# Altium schematic sheet OXCO_DaughterCard_Main.schdoc(OXCO_DaughterCard_Main)
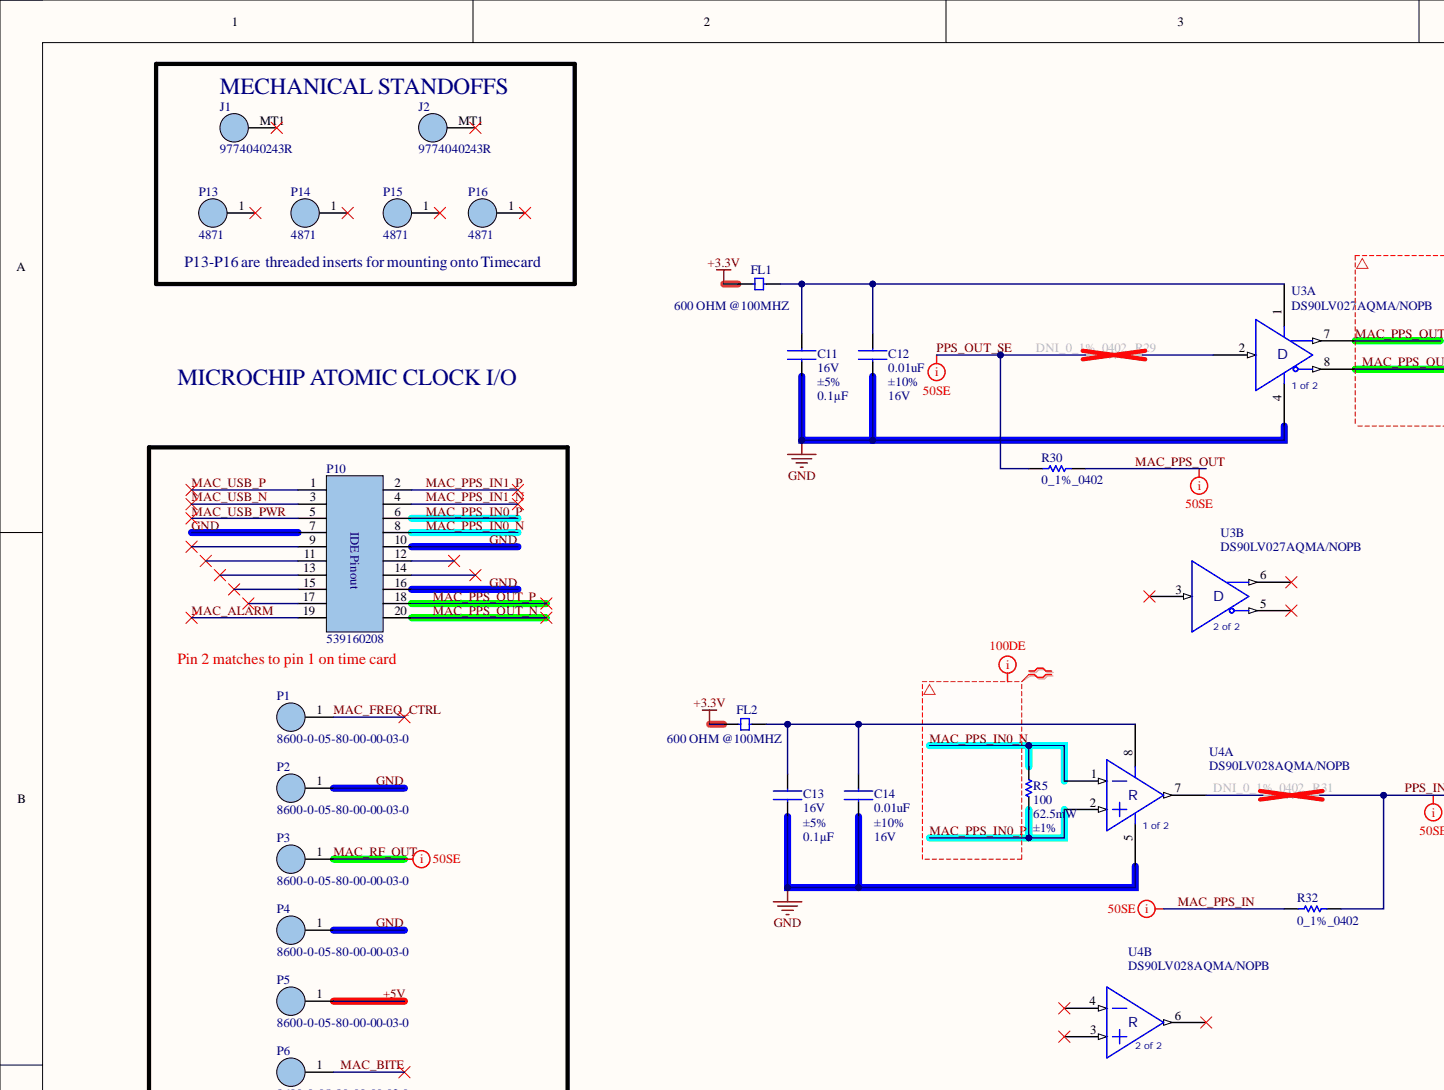
[diagram p0: OXCO_DaughterCard_Main.schdoc, top left]
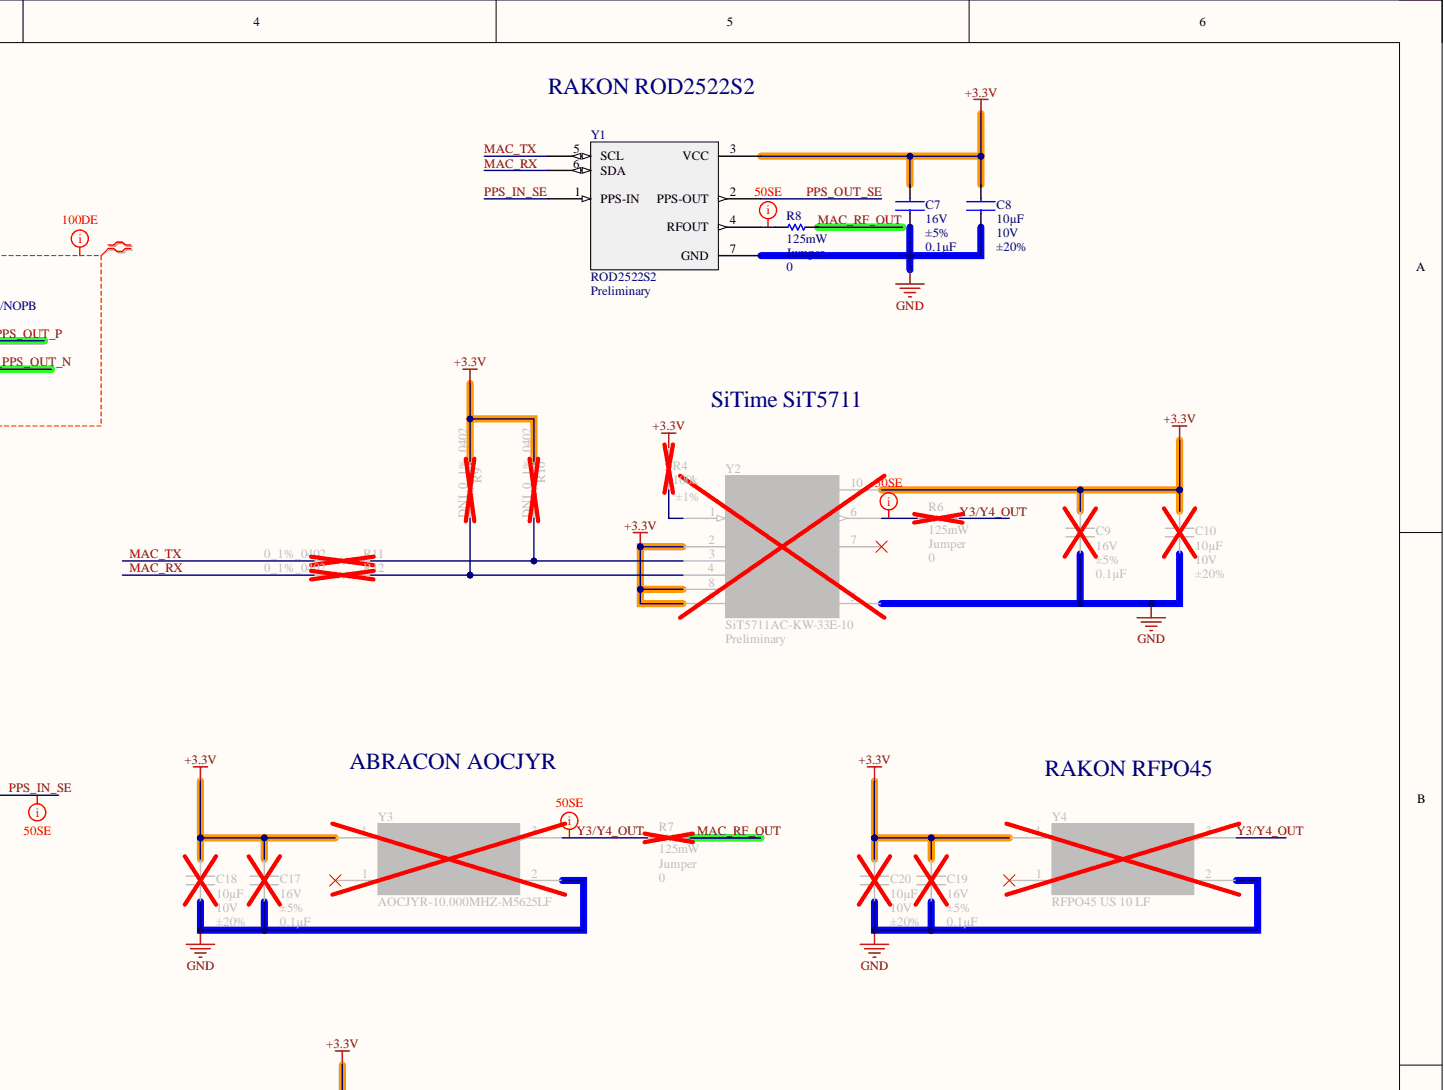
[diagram p1: OXCO_DaughterCard_Main.schdoc, top right]
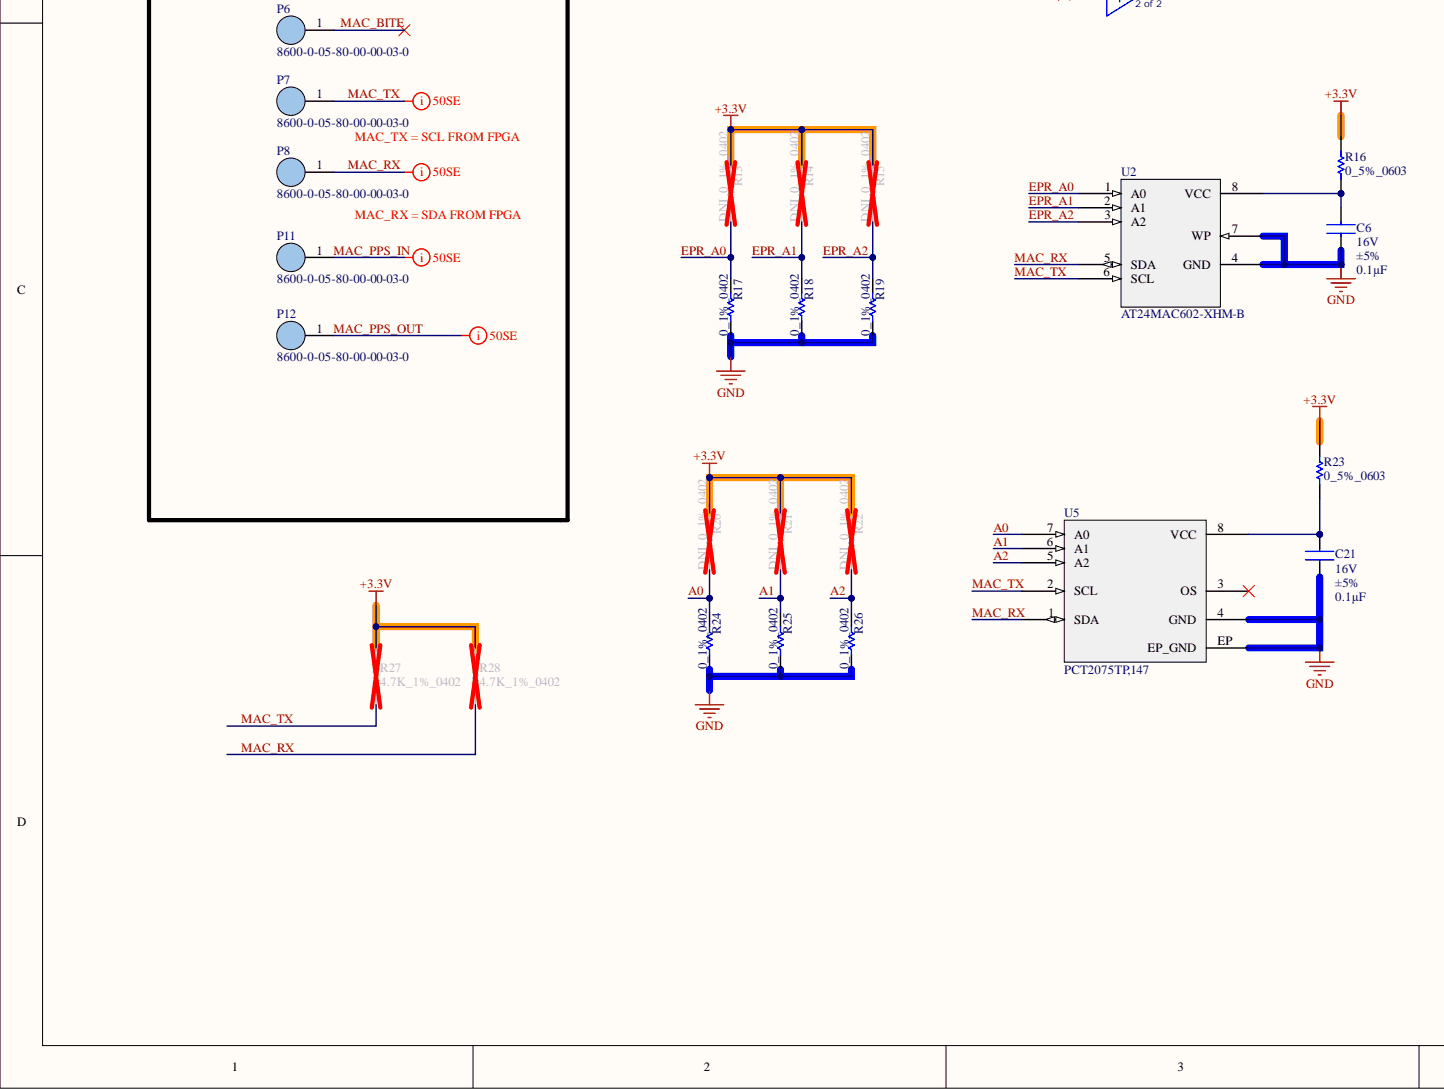
[diagram p2: OXCO_DaughterCard_Main.schdoc, bottom left]
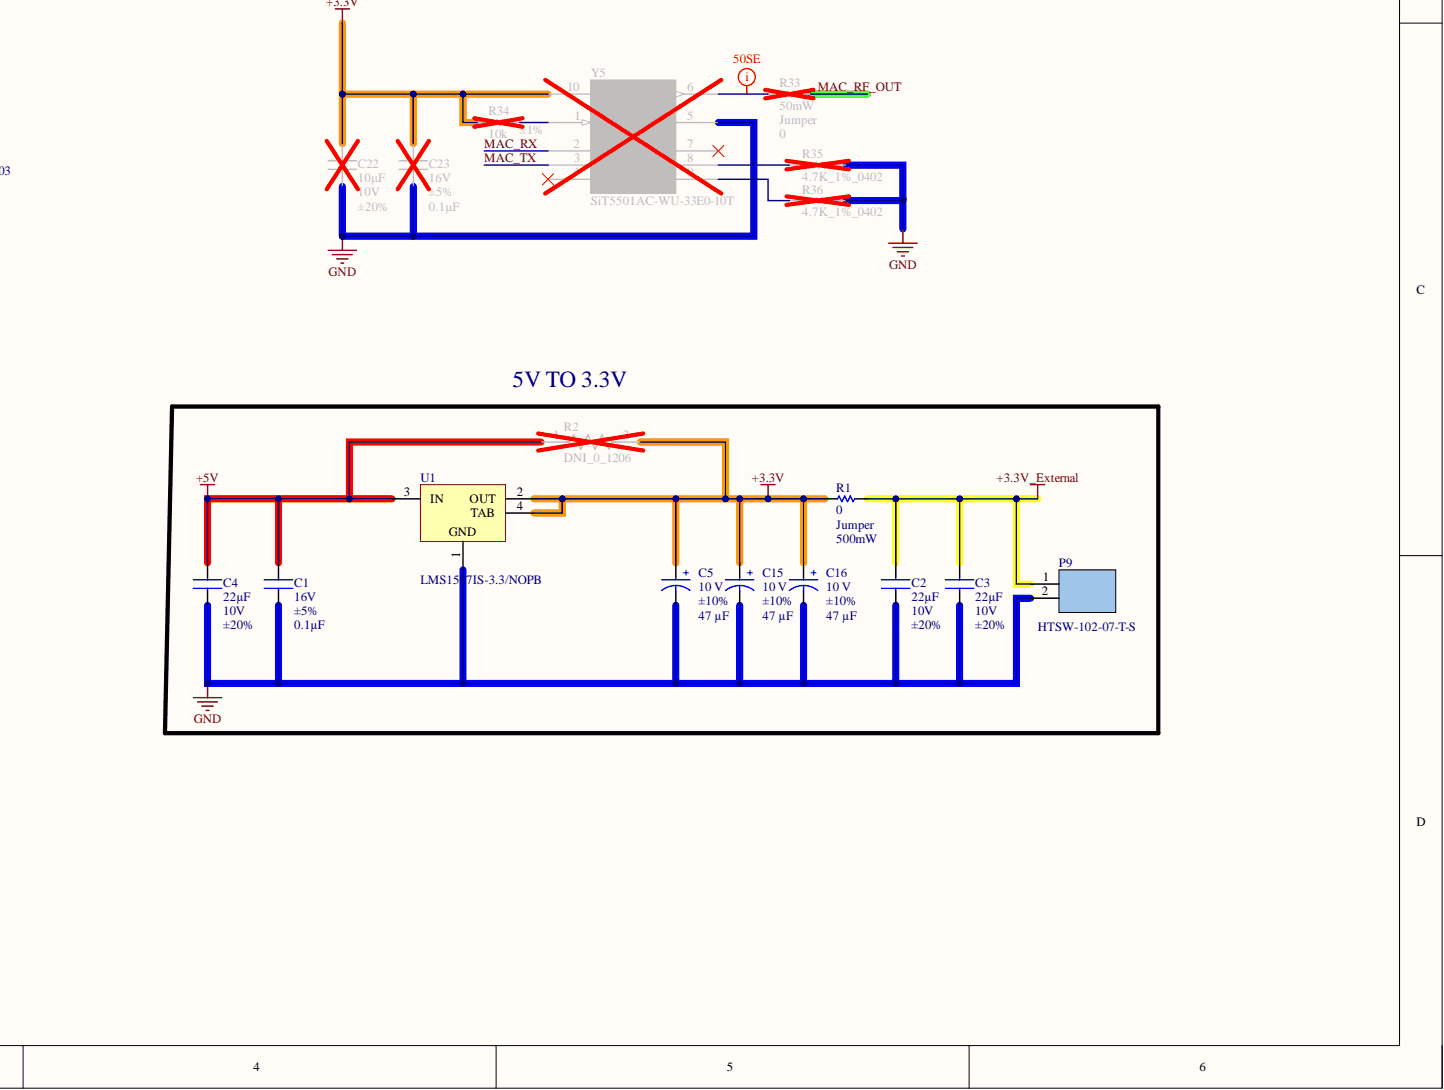
[diagram p3: OXCO_DaughterCard_Main.schdoc, bottom right]

## Parts
C1  Capacitance: 0.1µF  Tolerance: ±5%  Voltage Rating: 16V  [CAPC1005X055N]  (hidden comment: Samsung Electro-Mechanics_CL05B104JO5NNNC)
C2  Capacitance: 22µF  Tolerance: ±20%  Voltage Rating: 10V  [CAPC2013X140N]  (hidden comment: Murata_GRM21BR61A226ME51L)
C3  Capacitance: 22µF  Tolerance: ±20%  Voltage Rating: 10V  [CAPC2013X140N]  (hidden comment: Murata_GRM21BR61A226ME51L)
C4  Capacitance: 22µF  Tolerance: ±20%  Voltage Rating: 10V  [CAPC2013X140N]  (hidden comment: Murata_GRM21BR61A226ME51L)
C5  Tolerance: ±10%  [CAPMP3528X210N_T520]  (hidden comment: Kemet_T491B476K010AT)
C6  Capacitance: 0.1µF  Tolerance: ±5%  Voltage Rating: 16V  [CAPC1005X055N]  (hidden comment: Samsung Electro-Mechanics_CL05B104JO5NNNC)
C7  Capacitance: 0.1µF  Tolerance: ±5%  Voltage Rating: 16V  [CAPC1005X055N]  (hidden comment: Samsung Electro-Mechanics_CL05B104JO5NNNC)
C8  Capacitance: 10µF  Tolerance: ±20%  Voltage Rating: 10V  [CAPC1608X100N]  (hidden comment: Murata_GRM188D71A106MA73D)
C9  Capacitance: 0.1µF  Tolerance: ±5%  Voltage Rating: 16V  [CAPC1005X055N]  (hidden comment: Samsung Electro-Mechanics_CL05B104JO5NNNC)
C10  Capacitance: 10µF  Tolerance: ±20%  Voltage Rating: 10V  [CAPC1608X100N]  (hidden comment: Murata_GRM188D71A106MA73D)
C11  Capacitance: 0.1µF  Tolerance: ±5%  Voltage Rating: 16V  [CAPC1005X055N]  (hidden comment: Samsung Electro-Mechanics_CL05B104JO5NNNC)
C12  Capacitance: 0.01uF  Tolerance: ±10%  Voltage Rating: 16V  [CAPC1005X055N]  (hidden comment: Yageo_CC0402KRX7R7BB103)
C13  Capacitance: 0.1µF  Tolerance: ±5%  Voltage Rating: 16V  [CAPC1005X055N]  (hidden comment: Samsung Electro-Mechanics_CL05B104JO5NNNC)
C14  Capacitance: 0.01uF  Tolerance: ±10%  Voltage Rating: 16V  [CAPC1005X055N]  (hidden comment: Yageo_CC0402KRX7R7BB103)
C15  Capacitance: 47 µF  Tolerance: ±10%  Voltage Rating: 10 V  [CAPMP3528X210N_T520]  (hidden comment: Kemet_T491B476K010AT)
C16  Capacitance: 47 µF  Tolerance: ±10%  Voltage Rating: 10 V  [CAPMP3528X210N_T520]  (hidden comment: Kemet_T491B476K010AT)
C17  Capacitance: 0.1µF  Tolerance: ±5%  Voltage Rating: 16V  [CAPC1005X055N]  (hidden comment: Samsung Electro-Mechanics_CL05B104JO5NNNC)
C18  Capacitance: 10µF  Tolerance: ±20%  Voltage Rating: 10V  [CAPC1608X100N]  (hidden comment: Murata_GRM188D71A106MA73D)
C19  Capacitance: 0.1µF  Tolerance: ±5%  Voltage Rating: 16V  [CAPC1005X055N]  (hidden comment: Samsung Electro-Mechanics_CL05B104JO5NNNC)
C20  Capacitance: 10µF  Tolerance: ±20%  Voltage Rating: 10V  [CAPC1608X100N]  (hidden comment: Murata_GRM188D71A106MA73D)
C21  Capacitance: 0.1µF  Tolerance: ±5%  Voltage Rating: 16V  [CAPC1005X055N]  (hidden comment: Samsung Electro-Mechanics_CL05B104JO5NNNC)
C22  Capacitance: 10µF  Tolerance: ±20%  Voltage Rating: 10V  [CAPC1608X090N]  (hidden comment: Murata_GRM188R61A106ME69D)
C23  Capacitance: 0.1µF  Tolerance: ±5%  Voltage Rating: 16V  [CAPC1005X055N]  (hidden comment: Samsung Electro-Mechanics_CL05B104JO5NNNC)
FL1  Impedance @ Frequency: 600 OHM @100MHZ  [INDC1608X095N]  (hidden comment: TDK_MPZ1608S601ATA00)
FL2  Impedance @ Frequency: 600 OHM @100MHZ  [INDC1608X095N]  (hidden comment: TDK_MPZ1608S601ATA00)
J1  Manufacturer Part Number: 9774040243R  [TH000V_300DIAx400_001mt_9774040243R]  (hidden comment: Wurth_9774040243R)
J2  Manufacturer Part Number: 9774040243R  [TH000V_300DIAx400_001mt_9774040243R]  (hidden comment: Wurth_9774040243R)
P1  Manufacturer Part Number: 8600-0-05-80-00-00-03-0  [TH001V_109DIA_000mt_8600-0]  (hidden comment: Mill-Max_8600-0-05-80-00-00-03-0)
P2  Manufacturer Part Number: 8600-0-05-80-00-00-03-0  [TH001V_109DIA_000mt_8600-0]  (hidden comment: Mill-Max_8600-0-05-80-00-00-03-0)
P3  Manufacturer Part Number: 8600-0-05-80-00-00-03-0  [TH001V_109DIA_000mt_8600-0]  (hidden comment: Mill-Max_8600-0-05-80-00-00-03-0)
P4  Manufacturer Part Number: 8600-0-05-80-00-00-03-0  [TH001V_109DIA_000mt_8600-0]  (hidden comment: Mill-Max_8600-0-05-80-00-00-03-0)
P5  Manufacturer Part Number: 8600-0-05-80-00-00-03-0  [TH001V_109DIA_000mt_8600-0]  (hidden comment: Mill-Max_8600-0-05-80-00-00-03-0)
P6  Manufacturer Part Number: 8600-0-05-80-00-00-03-0  [TH001V_109DIA_000mt_8600-0]  (hidden comment: Mill-Max_8600-0-05-80-00-00-03-0)
P7  Manufacturer Part Number: 8600-0-05-80-00-00-03-0  [TH001V_109DIA_000mt_8600-0]  (hidden comment: Mill-Max_8600-0-05-80-00-00-03-0)
P8  Manufacturer Part Number: 8600-0-05-80-00-00-03-0  [TH001V_109DIA_000mt_8600-0]  (hidden comment: Mill-Max_8600-0-05-80-00-00-03-0)
P9  Manufacturer Part Number: HTSW-102-07-T-S  [TH002_254_508x254x838_000mt_HTSW]  (hidden comment: Samtec_HTSW-102-07-T-S)
P10  Manufacturer Part Number: 539160208  [SM020V_50_730x310x337_000mt_53916]  (hidden comment: Molex_539160208)
P11  Manufacturer Part Number: 8600-0-05-80-00-00-03-0  [TH001V_109DIA_000mt_8600-0]  (hidden comment: Mill-Max_8600-0-05-80-00-00-03-0)
P12  Manufacturer Part Number: 8600-0-05-80-00-00-03-0  [TH001V_109DIA_000mt_8600-0]  (hidden comment: Mill-Max_8600-0-05-80-00-00-03-0)
P13  4871  [Keystone_4871]
P14  4871  [Keystone_4871]
P15  4871  [Keystone_4871]
P16  4871  [Keystone_4871]
R1  Tolerance: Jumper  [RESC3225X070N]  (hidden comment: Stackpole Electronics, Inc._RMCF1210ZT0R00)
R2  DNI_0_1206  [FP-RC1206-MFG]  (no BOM)
R4  Resistance: 100k  Tolerance: ±1%  [RESC1005X040N]  (hidden comment: Panasonic_ERJ-2RKF1003X)
R5  Power (Watts): 62.5mW  [RESC1005X040N]  (hidden comment: Yageo_RC0402FR-07100RL)
R6  Power (Watts): 125mW  Tolerance: Jumper  [RESC1005X045N]  (hidden comment: Stackpole Electronics, Inc._HCJ0402ZT0R00)
R7  Power (Watts): 125mW  Tolerance: Jumper  [RESC1005X045N]  (hidden comment: Stackpole Electronics, Inc._HCJ0402ZT0R00)
R8  Power (Watts): 125mW  Tolerance: Jumper  [RESC1005X045N]  (hidden comment: Stackpole Electronics, Inc._HCJ0402ZT0R00)
R9  DNI_0_1%_0402  [RESC1005X40X25NL05T10]  (no BOM)
R10  DNI_0_1%_0402  [RESC1005X40X25NL05T10]  (no BOM)
R11  0_1%_0402  [RESC1005X40X25NL05T10]
R12  0_1%_0402  [RESC1005X40X25NL05T10]
R13  DNI_0_1%_0402  [RESC1005X40X25NL05T10]  (no BOM)
R14  DNI_0_1%_0402  [RESC1005X40X25NL05T10]  (no BOM)
R15  DNI_0_1%_0402  [RESC1005X40X25NL05T10]  (no BOM)
R16  0_5%_0603  [RESC1608X55X25ML10T15]
R17  0_1%_0402  [RESC1005X40X25NL05T10]
R18  0_1%_0402  [RESC1005X40X25NL05T10]
R19  0_1%_0402  [RESC1005X40X25NL05T10]
R20  DNI_0_1%_0402  [RESC1005X40X25NL05T10]  (no BOM)
R21  DNI_0_1%_0402  [RESC1005X40X25NL05T10]  (no BOM)
R22  DNI_0_1%_0402  [RESC1005X40X25NL05T10]  (no BOM)
R23  0_5%_0603  [RESC1608X55X25ML10T15]
R24  0_1%_0402  [RESC1005X40X25NL05T10]
R25  0_1%_0402  [RESC1005X40X25NL05T10]
R26  0_1%_0402  [RESC1005X40X25NL05T10]
R27  4.7K_1%_0402  [RESC1005X40X25LL05T05]
R28  4.7K_1%_0402  [RESC1005X40X25LL05T05]
R29  DNI_0_1%_0402  [RESC1005X40X25NL05T10]  (no BOM)
R30  0_1%_0402  [RESC1005X40X25NL05T10]
R31  DNI_0_1%_0402  [RESC1005X40X25NL05T10]  (no BOM)
R32  0_1%_0402  [RESC1005X40X25NL05T10]
R33  Power (Watts): 50mW  Tolerance: Jumper  [RESC0603X026N]  (hidden comment: Yageo_RC0201JR-070RL)
R34  Resistance: 10k  Tolerance: ±1%  [RESC0603X026N]  (hidden comment: Yageo_RC0201FR-0710KL)
R35  4.7K_1%_0402  [RESC1005X40X25LL05T05]
R36  4.7K_1%_0402  [RESC1005X40X25LL05T05]
U1  LMS1587IS-3.3/NOPB  [KTT0003B_L]
U2  Manufacturer Part Number: AT24MAC602-XHM-B  [TSSOP65P640X120-8N]  (hidden comment: Microchip_AT24MAC602-XHM-B)
U3  Manufacturer Part Number: DS90LV027AQMA/NOPB  [SOP127P600X175_8N]  (hidden comment: TI_DS90LV027AQMA/NOPB)  (parts A,B)
U4  Manufacturer Part Number: DS90LV028AQMA/NOPB  [SOP127P600X175_8N]  (hidden comment: TI_DS90LV028AQMA/NOPB)  (parts A,B)
U5  Manufacturer Part Number: PCT2075TP,147  [SON50P300X200X80-HS-9N_EP160x160_IPC]  (hidden comment: NXP_PCT2075TP,147)
Y1  Manufacturer Part Number: ROD2522S2  Part Status: Preliminary  [OSC007_762_2540x2200x1230]  (hidden comment: Rakon_ROD2522S2)  (no BOM)
Y2  Manufacturer Part Number: SiT5711AC-KW-33E-10  Part Status: Preliminary  Series: SiT5711  [SON150P700X619-10N_SiT5711]  (hidden comment: SiTime_SiT5711AC-KW-33E-10)  (no BOM)
Y3  Manufacturer Part Number: AOCJYR-10.000MHZ-M5625LF  Series: AOCJYR  [OSC004_600_970x750x410_AOCJYR]  (hidden comment: Abracon_AOCJYR-10.000MHZ-M5625LF)  (no BOM)
Y4  Manufacturer Part Number: RFPO45 US 10 LF  [OSC004_600_970x750x430_RFP045]  (hidden comment: Rakon_RFPO45 US 10 LF)  (no BOM)
Y5  Manufacturer Part Number: SiT5501AC-WU-33E0-10T  [SITIME_SPL-086-CQFN-010-C07050_REV_DRAFT]  (hidden comment: SiTime_SiT5501AC-WU-33E0-10T)  (no BOM)

## Nets
+3.3V: C5.1 C7.1 C8.1 C9.1 C10.1 C15.1 C16.1 C17.1 C18.1 C19.1 C20.1 C22.1 C23.1 FL1.1 FL2.1 R1.1 R2.2 R4.1 R9.1 R10.1 R13.1 R14.1 R15.1 R16.2 R20.1 R21.1 R22.1 R23.2 R27.2 R28.2 R34.1 U1.2(OUT) U1.4(TAB) Y1.3(VCC) Y2.2(NC) Y2.8(NC) Y2.9(NC) Y2.10(VDD) Y3.4(VCC) Y4.4(VCC) Y5.10(VDD)
+3.3V_External: C2.1 C3.1 P9.1 R1.2
+5V: C1.1 C4.1 P5.1 R2.1 U1.3(IN)
A0: R20.2 R24.1 U5.7(A0)
A1: R21.2 R25.1 U5.6(A1)
A2: R22.2 R26.1 U5.5(A2)
EPR_A0: R13.2 R17.1 U2.1(A0)
EPR_A1: R14.2 R18.1 U2.2(A1)
EPR_A2: R15.2 R19.1 U2.3(A2)
GND: C1.2 C2.2 C3.2 C4.2 C5.2 C6.2 C7.2 C8.2 C9.2 C10.2 C11.2 C12.2 C13.2 C14.2 C15.2 C16.2 C17.2 C18.2 C19.2 C20.2 C21.2 C22.2 C23.2 P2.1 P4.1 P9.2 P10.7 P10.10 P10.16 R17.2 R18.2 R19.2 R24.2 R25.2 R26.2 R35.1 R36.1 U1.1 U2.4(GND) U2.7(WP) U3.4(D) U4.5(R) U5.4(GND) U5.EP(EP_GND) Y1.7(GND) Y2.5(GND) Y3.2(GND) Y4.2(GND) Y5.5(GND)
MAC_ALARM: P10.19
MAC_BITE: P6.1
MAC_FREQ_CTRL: P1.1
MAC_PPS_IN: P11.1 R32.1
MAC_PPS_IN0_N: P10.8 R5.1 U4.1
MAC_PPS_IN0_P: P10.6 R5.2 U4.2
MAC_PPS_IN1_N: P10.4
MAC_PPS_IN1_P: P10.2
MAC_PPS_OUT: P12.1 R30.2
MAC_PPS_OUT_N: P10.20 U3.8
MAC_PPS_OUT_P: P10.18 U3.7
MAC_RF_OUT: P3.1 R7.2 R8.2 R33.2
MAC_RX: P8.1 R12.1 R28.1 U2.5(SDA) U5.1(SDA) Y1.6(SDA) Y5.2(NC)
MAC_TX: P7.1 R11.1 R27.1 U2.6(SCL) U5.2(SCL) Y1.5(SCL) Y5.3(NC)
MAC_USB_N: P10.3
MAC_USB_P: P10.1
MAC_USB_PWR: P10.5
PPS_IN_SE: R31.2 R32.2 Y1.1(PPS-IN)
PPS_OUT_SE: R29.1 R30.1 Y1.2(PPS-OUT)
Y3/Y4_OUT: R6.2 R7.1 Y3.3(OUT) Y4.3(OUT)
NetC6_1: C6.1 R16.1 U2.8(VCC)
NetC11_1: C11.1 C12.1 FL1.2 U3.1
NetC13_1: C13.1 C14.1 FL2.2 U4.8
NetC21_1: C21.1 R23.1 U5.8(VCC)
NetR4_2: R4.2 Y2.1(OE/NC)
NetR6_1: R6.1 Y2.6(CLK)
NetR8_1: R8.1 Y1.4(RFOUT)
NetR9_2: R9.2 R12.2 Y2.4(NC)
NetR10_2: R10.2 R11.2 Y2.3(NC)
NetR29_2: R29.2 U3.2
NetR31_1: R31.1 U4.7
NetR33_1: R33.1 Y5.6(CLK)
NetR34_2: R34.2 Y5.1(OE)
NetR35_2: R35.2 Y5.8(NC)
NetR36_2: R36.2 Y5.9(NC)

Not connected: J1 MT1; J2 MT1; P10 9 11 12 13 14 15 17; P13 1; P14 1; P15 1; P16 1; U3 3(D) 5 6; U4 3 4 6(R); U5 3(OS); Y2 7(RSVD); Y3 1(NC); Y4 1(NC); Y5 4(NC) 7(NC)

## Library data of the parts
C1 C6 C7 C9 C11 C13 C17 C19 C21 = CAP-CMP-00271-3: CAP, CER, X7R, 0.1UF, 5%, 16V, 0402, 0.55MM T; Polarization: Non-polar; Applications: General Purpose; Case/Package: 0402 (1005 Metric); Category: Ceramic Capacitors; Component Kind: Standard; Composition: Ceramic; Datasheet URL: https://www.digikey.com/en/products/detail/samsung-electro-mechanics/CL05B104JO5NNNC/3887163?s=N4IgTCBcDaIMIBkAMBWAQgRiQFgFIHkUA5EuEAXQF8g; Height: 0.022" (0.55mm); Manufacturer: Samsung Electro-Mechanics; Manufacturer Part Number: CL05B104JO5NNNC; Max Operating Temperature: 125°C; Min Operating Temperature: -55°C; Mounting Type: Surface Mount, MLCC; RoHS: Yes; Series: CL; Size / Dimension: 0.039" L x 0.020" W (1.00mm x 0.50mm); Supplier 1: Digikey; Supplier Part Number 1: 1276-1505-1-ND; Temperature Coefficient: X7R; Library Name: FRL Altium Server
C2-C4 = CAP-CMP-00519-3: CAP, CER, X5R, 22UF, 20%, 10V, 0805, 1.40MM T; Manufacturer: Murata; Polarization: Non-polar; Applications: General Purpose; Case/Package: 0805 (2013 Metric); Category: Ceramic Capacitors; Component Kind: Standard; Composition: Ceramic; Datasheet URL: https://www.digikey.com/en/products/detail/murata-electronics/GRM21BR61A226ME51L/5027595?s=N4IgTCBcDaIOICUCyYCMAhBA2VBBMYWSAogKyoAyIAugL5A; Height: 0.055" (1.40mm); Manufacturer Part Number: GRM21BR61A226ME51L; Max Operating Temperature: 85°C; Min Operating Temperature: -55°C; Mounting Type: Surface Mount, MLCC; RoHS: Yes; Series: GRM21BR61A; Size / Dimension: 0.079" L x 0.049" W (2.00mm x 1.25mm); Supplier 1: Digikey; Supplier Part Number 1: 490-10511-1-ND; Temperature Coefficient: X5R; Library Name: FRL Altium Server
C5 = CAP-CMP-00042-1: CAP TANT 47UF 10% 10V 1411; Manufacturer: Kemet; Capacitance: 47 µF; ESR (Equivalent Series Resistance): 1Ohm; Polarization: Polar; Type: Molded; Case/Package: 1411 (3528 Metric); Category: Tantalum Capacitors; Component Kind: Standard; Composition: Tantalum; Datasheet URL: https://www.digikey.com/en/products/detail/kemet/T491B476K010AT/3724805; Features: General Purpose; Height: 0.083" (2.10mm); Manufacturer Part Number: T491B476K010AT; Max Operating Temperature: 125°C; Min Operating Temperature: -55°C; Mounting Type: Surface Mount; RoHS: Yes; Series: T491; Size / Dimension: 0.138" L x 0.110" W (3.50mm x 2.80mm); Supplier 1: Digikey; Supplier Part Number 1: 399-9728-1-ND; Voltage Rating: 10 V; Library Name: FRL Altium Server
C8 C10 C18 C20 = CAP-CMP-00449-3: CAP, CER, X7T, 10UF, 20%, 10V, 0603, 1.00MM T; Manufacturer: Murata; Polarization: Non-polar; Applications: General Purpose; Case/Package: 0603 (1608 Metric); Category: Ceramic Capacitors; Component Kind: Standard; Composition: Ceramic; Datasheet URL: https://www.digikey.com/en/products/detail/murata-electronics/GRM188D71A106MA73D/3900467?s=N4IgTCBcDaIOICUCyBGAHGgIgdhQQRQAYA2JPbAZkxAF0BfIA; Height: 0.039" (1.00mm); Manufacturer Part Number: GRM188D71A106MA73D; Max Operating Temperature: 125°C; Min Operating Temperature: -55°C; Mounting Type: Surface Mount, MLCC; RoHS: Yes; Series: GRM188D71A; Size / Dimension: 0.063" L x 0.031" W (1.60mm x 0.80mm); Supplier 1: Digikey; Supplier Part Number 1: 490-7200-1-ND; Temperature Coefficient: X7T; Library Name: FRL Altium Server
C12 C14 = CAP-CMP-00257-3: CAP, CER, X7R, 0.01UF, 10%, 16V, 0402, 0.55MM T; Manufacturer: Yageo; Polarization: Non-polar; Applications: General Purpose; Case/Package: 0402 (1005 Metric); Category: Ceramic Capacitors; Component Kind: Standard; Composition: Ceramic; Datasheet URL: https://www.digikey.com/en/products/detail/yageo/CC0402KRX7R7BB103/302776?s=N4IgTCBcDaIMJwAwBZFgNICUAaB2TuAQoQIyIDMIAugL5A; Height: 0.022" (0.55mm); Manufacturer Part Number: CC0402KRX7R7BB103; Max Operating Temperature: 125°C; Min Operating Temperature: -55°C; Mounting Type: Surface Mount, MLCC; RoHS: Yes; Series: CC; Size / Dimension: 0.039" L x 0.020" W (1.00mm x 0.50mm); Supplier 1: Digikey; Supplier Part Number 1: 311-1042-1-ND; Temperature Coefficient: X7R; Library Name: FRL Altium Server
C15 C16 = CAP-CMP-00042-1: CAP TANT 47UF 10% 10V 1411; Manufacturer: Kemet; ESR (Equivalent Series Resistance): 1Ohm; Polarization: Polar; Type: Molded; Case/Package: 1411 (3528 Metric); Category: Tantalum Capacitors; Component Kind: Standard; Composition: Tantalum; Datasheet URL: https://www.digikey.com/en/products/detail/kemet/T491B476K010AT/3724805; Features: General Purpose; Height: 0.083" (2.10mm); Manufacturer Part Number: T491B476K010AT; Max Operating Temperature: 125°C; Min Operating Temperature: -55°C; Mounting Type: Surface Mount; RoHS: Yes; Series: T491; Size / Dimension: 0.138" L x 0.110" W (3.50mm x 2.80mm); Supplier 1: Digikey; Supplier Part Number 1: 399-9728-1-ND; Library Name: FRL Altium Server
C22 = CMP-CAP-00380-1: CAP, CER, X5R, 10UF, 20%, 10V, 0603, 0.80MM T; Manufacturer: Murata; Polarization: Non-polar; Applications: General Purpose; Case/Package: 0603 (1608 Metric); Category: Ceramic Capacitors; Component Kind: Standard; Composition: Ceramic; Datasheet URL: https://www.digikey.com/en/products/detail/murata-electronics/GRM188R61A106ME69D/5027560?s=N4IgTCBcDaIOICUCyBGAHGhA2FBBFADFkgKJYCcAIiALoC%2BQA; Height: 0.035" (0.90mm); Manufacturer Part Number: GRM188R61A106ME69D; Max Operating Temperature: 85°C; Min Operating Temperature: -55°C; Mounting Type: Surface Mount, MLCC; RoHS: Yes; Series: GRM188R61A; Size / Dimension: 0.063" L x 0.031" W (1.60mm x 0.80mm); Supplier 1: Digikey; Supplier Part Number 1: 490-10475-1-ND; Temperature Coefficient: X5R; Library Name: Meta RLR Altium Enterprise Server
C23 = CMP-CAP-00082-2: CAP, CER, X7R, 0.1UF, 5%, 16V, 0402, 0.55MM T; Polarization: Non-polar; Applications: General Purpose; Case/Package: 0402 (1005 Metric); Category: Ceramic Capacitors; Component Kind: Standard; Composition: Ceramic; Datasheet URL: https://www.digikey.com/en/products/detail/samsung-electro-mechanics/CL05B104JO5NNNC/3887163?s=N4IgTCBcDaIMIBkAMBWAQgRiQFgFIHkUA5EuEAXQF8g; Height: 0.022" (0.55mm); Manufacturer: Samsung Electro-Mechanics; Manufacturer Part Number: CL05B104JO5NNNC; Max Operating Temperature: 125°C; Min Operating Temperature: -55°C; Mounting Type: Surface Mount, MLCC; RoHS: Yes; Series: CL; Size / Dimension: 0.039" L x 0.020" W (1.00mm x 0.50mm); Supplier 1: Digikey; Supplier Part Number 1: 1276-1505-1-ND; Temperature Coefficient: X7R; Library Name: Meta RLR Altium Enterprise Server
FL1 FL2 = FER-CMP-00057-5: FERRITE BEAD, 600 OHM @100MHZ, 25%, 1A, 0.150 OHM DCR, 0603, 0.95MM T; Manufacturer: TDK; DC Resistance (Max): 0.15; Filter Type: Power Line; Lines: 1; Polarization: Non-polar; Tolerance: 0.25%; Case/Package: 0603 (1608 Metric); Category: Ferrite Beads and Chips; Component Kind: Standard; Current Rating: 1A; Datasheet URL: https://product.tdk.com/en/system/files?file=dam/doc/product/emc/emc/beads/catalog/beads_commercial_power_mpz1608_en.pdf; Height: 0.037" (0.95mm); Manufacturer Part Number: MPZ1608S601ATA00; Max Operating Temperature: 125°C; Min Operating Temperature: -55°C; RoHS: Yes; Series: MPZ; Size / Dimension: 0.063" L x 0.032" W (1.60mm x 0.80mm); Supplier 1: Digi-Key; Supplier Part Number 1: 445-2205-1-ND; Library Name: FRL Altium Server
J1 J2 = CON-CMP-00164-1: STANDOFF, ROUND, M2X0.4 STEEL 4MM, 9774040243R; Manufacturer: Wurth Elektronik; System: connector; Category: Board Spacers, Standoffs; Component Kind: Standard; Contact Material: Steel; Datasheet URL: https://www.digikey.com/en/products/detail/w%C3%BCrth-elektronik/9774040243R/5320633?s=N4IgTCBcDaIJwHYEBYAMazIMwCUQF0BfIA; Height: 0.157" (4.00mm); Max Operating Temperature: 150°C; Min Operating Temperature: -55°C; Mounting Type: Through Hole, Vertical; RoHS: Yes; Screw Thread: M2x0.4; Series: WA-SMSI; Supplier 1: Digikey; Supplier Part Number 1: 732-7090-1-ND; Type: Round Standoff; Library Name: FRL Altium Server
P1-P8 P11 P12 = CON-CMP-00162-1: CONN, PC PIN, PRESS-FIT, 10.16MM LENGTH, TIN, TH; Manufacturer: Mill-Max Manufacturing Corp.; Flange Diameter: 0.072" (1.83mm); Insulation: Non-Insulated; Mounting Hole Diameter: 0.043" (1.09mm); Pin Size - Above Flange: 0.040" (1.02mm) Dia; Pin Size - Below Flange: 0.035" (0.89mm) Square; Rows: 1; System: connector; Category: Terminals - PC Pin, Single Post Connectors; Component Kind: Standard; Contact Finish: Tin; Contact Material: Brass Alloy; Datasheet URL: https://www.digikey.com/en/products/detail/mill-max-manufacturing-corp/8600-0-05-80-00-00-03-0/7775333?s=N4IgTCBcDaIBwDYAMSC0akFZVw39AzOiALoC%2BQA; Length - Above Flange: 0.364" (9.25mm); Length - Below Flange: 0.060" (1.52mm); Length - Overall: 0.460" (11.68mm); Max Operating Temperature: 125°C; Min Operating Temperature: -55°C; Mounting Type: Through Hole, Vertical; RoHS: Yes; Series: 8600; Signal Pins: 1; Supplier 1: Digikey; Supplier Part Number 1: 8600-0-05-80-00-00-03-0-ND; Terminal Style: Single End; Terminal Type: PC Pin; Termination: Press-Fit, Square; Library Name: FRL Altium Server
P9 = CON-CMP-00061-3: CONN, PIN, HEADER, 2POS, 2.54MM PITCH, 8.38MM H, VERT, TIN, TH; Manufacturer: Samtec Inc.; Current Rating: Varies by Wire Gauge; Pitch: 0.100" (2.54mm); Rows: 1; Shrouded: Unshrouded; Signal Pins: 2; Style: Board to Board or Cable; System: connector; Category: Rectangular Connectors - Headers, Male Pins; Color: Natural; Component Kind: Standard; Connector Type: Header; Contact Finish: Tin; Contact Material: Phosphor Bronze; Contact Type: Male Pin; Datasheet URL: https://www.digikey.com/en/products/detail/samtec-inc/HTSW-102-07-T-S/2664207?s=N4IgTCBcDaIBIBUDKB1AtARgAxjVg7GgmkiALoC%2BQA; Fastening Type: Push-Pull; Height: 8.38mm; Max Operating Temperature: 105°C; Min Operating Temperature: -55°C; Mounting Type: Through Hole, Vertical; RoHS: Yes; Series: HTSW-102; Supplier 1: Digikey; Supplier Part Number 1: SAM8921-ND; Termination: Solder; Library Name: FRL Altium Server
P10 = CON-CMP-00163-2: CONN, B2B/FPC, HEADER, 20-POS, 0.5MM PITCH, 4.0MM H, VERT, GOLD, SMT; Manufacturer: Molex; Connector Type: Plug, Outer Shroud Contacts; Current Rating: 0.5A; Mated Stacking Heights: 4mm; Number of Positions/Contacts: 20; Pitch: 0.50mm; Rows: 2; Style: Board to Board, Signal; System: connector; Voltage Rating: 50V; Category: Rectangular Connectors - Arrays, Edge Type, Mezzanine (Board to Board); Component Kind: Standard; Contact Finish: Gold; Datasheet URL: https://www.digikey.com/en/products/detail/molex/0539160208/1059866; Height: 0.133" (3.37mm); Max Operating Temperature: 105°C; Min Operating Temperature: -40°C; Mounting Type: Surface Mount, Vertical; RoHS: Yes; Series: SlimStack 53916; Signal Pins: 20; Supplier 1: Digikey; Supplier Part Number 1: WM9212CT-ND; Library Name: FRL Altium Server
P13-P16 = Keystone_4871: Round Standoff Threaded #2-56 Steel 0.063" (1.60mm) 1/16"; System: connector; Component Kind: Standard; Manufacturer: Keystone; Manufacturer Part Number: 4871; Mounting Type: Through Hole, Vertical; RoHS: Yes; Library Name: 846-01710_SCH_OCXO_DaughterCard.SCHLIB
R1 = RES-CMP-00829-3: RES, 0.0 OHM, 3A, 1/2W, 1210; Power (Watts): 500mW; Resistance: 0; Case/Package: 1210 (3225 Metric); Category: Chip Resistor - Surface Mount; Component Kind: Standard; Composition: Thick Film; Datasheet URL: https://www.digikey.com/en/products/detail/stackpole-electronics-inc/RMCF1210ZT0R00/1715043?s=N4IgTCBcDaIEoFkDCAxAjGNAGAWgFSziyxAF0BfIA; Features: Automotive AEC-Q200; Height: 0.028" (0.70mm); Manufacturer: Stackpole Electronics, Inc.; Manufacturer Part Number: RMCF1210ZT0R00; Max Operating Temperature: 155°C; Min Operating Temperature: -55°C; Ratings: AEC-Q200; RoHS: Yes; Series: RMCF; Size / Dimension: 0.126" L x 0.098" W (3.20mm x 2.50mm); Supplier 1: Digikey; Supplier Part Number 1: RMCF1210ZT0R00CT-ND; Library Name: FRL Altium Server
R2 = CMP-2100-03681-2: RES SMD 0 OHM JUMPER 1/4W 1206; Manufacturer: YAGEO; Manufacturer Part Number: RC1206JR-070RL; Power Rating: 0.25W; Resistance: 0Ω; Temperature Coefficient: 300ppm/°C; Tolerance: 5%; Value: 0Ω; Voltage Rating: 200V; Automotive: No; Category: Res; ComponentLink2Description: Datasheet; ComponentLink2URL: https://www.yageo.com/upload/media/product/productsearch/datasheet/rchip/PYu-RC_Group_51_RoHS_L_10.pdf; Composition: Thick Film; Device Class L1: Passive Components; Device Class L2: Resistors; Device Class L3: Chip SMD Resistors; Digikey Description: RES SMD 0 OHM JUMPER 1/4W 1206; Footprint Url: https://www.yageo.com/upload/website/yageo_PYu-R_Mount_10_19050818_343.pdf; Height: 0.65mm; Ipc Land Pattern Name: RESC310160X55; Lead Free: Yes; Library Name: Altium Content Vault; Mouser Description: Resistor: thick film; SMD; 1206; 0O; 0.25W; ±5%; -55÷155°C; Octopart: https://octopart.com/rc1206jr-070rl-yageo-40025601; Package: RC1206; RoHS: TRUE; Series: RC; Temperature: -55°C to +155°C; Temperature Range High: +155°C; Temperature Range Low: -55°C
R4 = RES-CMP-00289-3: RES, 100K OHM, 1%, 1/10W, 100PPM/C, 0402; Power (Watts): 100mW; Temperature Coefficient: ±100ppm/°C; Case/Package: 0402 (1005 Metric); Category: Chip Resistor - Surface Mount; Component Kind: Standard; Composition: Thick Film; Datasheet URL: https://www.digikey.com/en/products/detail/panasonic-electronic-components/ERJ-2RKF1003X/192081?s=N4IgTCBcDaIKICUBSBaMCDSAxAjABjwGYANEAXQF8g; Features: Automotive AEC-Q200; Height: 0.016" (0.40mm); Manufacturer: Panasonic; Manufacturer Part Number: ERJ-2RKF1003X; Max Operating Temperature: 155°C; Min Operating Temperature: -55°C; Ratings: AEC-Q200; RoHS: Yes; Series: ERJ-2RK; Size / Dimension: 0.039" L x 0.020" W (1.00mm x 0.50mm); Supplier 1: Digikey; Supplier Part Number 1: P100KLCT-ND; Library Name: FRL Altium Server
R5 = RES-CMP-00538-3: RES SMD 100 OHM 1% 1/16W 0402; Manufacturer: Yageo; Resistance: 100; Temperature Coefficient: ±100ppm/°C; Case/Package: 0402 (1005 Metric); Category: Chip Resistor - Surface Mount; Component Kind: Standard; Composition: Thick Film; Datasheet URL: https://www.yageo.com/upload/media/product/productsearch/datasheet/rchip/PYu-RC_Group_51_RoHS_L_11.pdf; Height: 0.016" (0.40mm); Manufacturer Part Number: RC0402FR-07100RL; Max Operating Temperature: 155°C; Min Operating Temperature: -55°C; RoHS: Yes; Series: RC_L; Size / Dimension: 0.039" L x 0.020" W (1.00mm x 0.50mm); Supplier 1: Digi-Key; Supplier Part Number 1: 311-100LRCT-ND; Tolerance: ±1%; Library Name: FRL Altium Server
R6-R8 = RES-CMP-00382-3: RES, 0.0 OHM, 1/8W, 6.5A, 0402; Resistance: 0; Case/Package: 0402 (1005 Metric); Category: Chip Resistor - Surface Mount; Component Kind: Standard; Composition: Metal Foil; Datasheet URL: https://www.digikey.com/en/products/detail/stackpole-electronics-inc/HCJ0402ZT0R00/4357659?s=N4IgTCBcDaIBIGEBSAGALCsAtAKigSiiiALoC%2BQA; Features: Automotive AEC-Q200; Height: 0.018" (0.45mm); Manufacturer: Stackpole Electronics, Inc.; Manufacturer Part Number: HCJ0402ZT0R00; Max Operating Temperature: 155°C; Min Operating Temperature: -55°C; RoHS: Yes; Series: HCJ; Size / Dimension: 0.039" L x 0.020" W (1.00mm x 0.50mm); Supplier 1: Digikey; Supplier Part Number 1: HCJ0402ZT0R00CT-ND; Library Name: FRL Altium Server
R9-R15 R17-R22 R24-R26 R29-R32 = CMP-1011-00001-2: Manufacturer: Yageo / Phycomp; Part Number: RC0402JR-070RL; Library Name: Altium Content Vault
  R11 R12 R17-R19 R24-R26 R30 R32: Component Kind: Standard
R16 R23 = CMP-2100-03667-1: Chip Resistor, 0 Ohm, +/- 5%, 0.1 W, -55 to 155 degC, 0603 (1608 Metric), RoHS, Tape and Reel; Manufacturer: Yageo; Power Rating: 100mW; Resistance: 0mOhm; Temperature Coefficient: 200ppm/degreesC; Voltage Rating: 50V; Case Code (Imperial): 0603; Case Code (Metric): 1608; Case/Package: 0603; Component Kind: Standard; Composition: ThickFilm; Contact Plating: Tin; Datasheet URL: http://www.yageo.com/NewPortal/yageodocoutput?fileName=/pdf/R-Chip/PYu-RC_Group_51_RoHS_L_5.pdf; Datasheet Version: Rev. 5, 10/2016; Depth: 0.8mm; Height: 0.45mm; Lead Free: LeadFree; Length: 1.6mm; Library Name: Altium Content Vault; Manufacturer URL: http://www.yageo.com/; Max Operating Temperature: 155degC; Military Standard: Not; Min Operating Temperature: -55degC; Number of Pins: 2; Package Description: 2-Pin Surface Mount Device, Body 1.6 x 0.8 mm; Package Quantity: 5000; Package Reference: 0603; Packaging: TapeandReel; Radiation Hardening: No; REACH SVHC: NoSVHC; RoHSCompliant: true; Termination: SMD/SMT; Tolerance: 5%; Voltage Rating (DC): 50V; Width: 0.8mm
R27 R28 R35 R36 = CMP-2002-01154-4: Chip Resistor, 4.7 KOhm, +/- 1%, 0.1 W, -55 to 155 degC, 0402 (1005 Metric), RoHS, Tape and Reel; PartNumber: ERJ-2RKF4701X; Case\/Package: 0402; Power Rating: 100 mW; Voltage Rating: 50 V; Case Code (Imperial): 0402; Case Code (Metric): 1005; Component Kind: Standard; ComponentLink1Description: Manufacturer URL; ComponentLink1URL: http://www.panasonic.com/; ComponentLink2Description: Datasheet; ComponentLink2URL: http://industrial.panasonic.com/cdbs/www-data/pdf/RDA0000/AOA0000C86.pdf; DatasheetVersion: 04/2015; Height: 0.35 mm; Length: 1 mm; Library Name: Altium Content Vault; Max Operating Temperature: 155 degC; Min Operating Temperature: -55 degC; Mount: Surface Mount; Mounting Technology: Surface Mount; Package Quantity: 1; PackageDescription: 2-Pin Surface Mount Device, Body 1 x 0.5 mm; PackageReference: 0402; Packaging: Cut Tape; Pins: 2; Radiation Hardening: No; REACH SVHC: Yes; Resistance: 4.7 KOhm; RoHSCompliant: true; Tolerance: 1%; Width: 0.5 mm
R33 = CMP-RES-00843-1: RES, 0.0 OHM, 0.5A, 1/20W, 0201; Manufacturer: Yageo; Resistance: 0; Case/Package: 0201 (0603 Metric); Category: Chip Resistor - Surface Mount; Component Kind: Standard; Composition: Thick Film; Datasheet URL: https://www.yageo.com/upload/media/product/productsearch/datasheet/rchip/PYu-RC_Group_51_RoHS_L_11.pdf; Height: 0.010" (0.26mm); Manufacturer Part Number: RC0201JR-070RL; Series: RC; Size / Dimension: 0.024" L x 0.012" W (0.60mm x 0.30mm); Supplier 1: Digi-Key; Supplier Part Number 1: 311-0.0NCT-ND; Library Name: Meta RLR Altium Enterprise Server
R34 = CMP-RES-00602-1: RES, 10K OHM, 1%, 1/20W, 200PPM/C, 0201; Manufacturer: Yageo; Power (Watts): 50mW; Temperature Coefficient: ±200ppm/°C; Case/Package: 0201 (0603 Metric); Category: Chip Resistor - Surface Mount; Component Kind: Standard; Manufacturer Part Number: RC0201FR-0710KL; RoHS: Yes; Series: RC_L; Size / Dimension: 0.024" L x 0.012" W (0.60mm x 0.30mm); Library Name: Meta RLR Altium Enterprise Server
U1 = CMP-0062-00189-5: 3A Low Dropout Fast Response Regulators, 3-pin TO-263, Pb-Free; Manufacturer: Texas Instruments; CompType: LDO IC; High-Reliability: Y; PackageTopMarking: NSUZXYTTE#*LMS1587*IS-3.3; PowerWise: N; Code_JEDEC: MO-169-AA; Component Kind: Standard; ComponentLink1Description: Datasheet URL; ComponentLink1URL: http://www.ti.com/general/docs/lit/getliterature.tsp?genericPartNumber=LMS1587&fileType=pdf; Library Name: Altium Content Vault; PackageDescription: TO-263, Molded, .400 x .390 x .180in, 3 Lead, .1in Pitch, Surface Mount; PackageReference: KTT0003B; PartNumber: LMS1587IS-3.3/NOPB; PinCount: 3; RoHS: Y
U2 = MEM-CMP-00010-1: IC, EEPROM, AT24MAC602, 2K-BIT (256 X 8), 1MHZ, I2C, 1.7V - 5.5V, TSSOP-8; Manufacturer: Microchip Technology; Access Time: 550 ns; Memory Interface: I²C; Memory Size: 2Kb (256 x 8); Memory Type: Non-Volatile; Voltage - Supply: 1.7V ~ 5.5V; Case/Package: 8-TSSOP (0.173", 4.40mm Width); Category: Memory; Clock Frequency: 1 MHz; Component Kind: Standard; Datasheet URL: https://www.digikey.com/en/products/detail/microchip-technology/AT24MAC602-XHM-B/5057027; Max Operating Temperature: 85°C; Memory Format: EEPROM; Min Operating Temperature: -40°C; Mounting Type: Surface Mount; RoHS: Yes; Supplier 1: Digikey; Supplier Part Number 1: AT24MAC602-XHM-B-ND; Technology: EEPROM; Library Name: FRL Altium Server
U3 = INT-CMP-00018-2: IC, DRIVER, DS90LV027AQ, DUAL, DIFF, LVDS, 600MBPS, SOIC-8; Manufacturer: Texas Instruments; Number of Drivers/Receivers: 2/0; Voltage - Supply: 3V ~ 3.6V; Case/Package: 8-SOIC (0.154", 3.90mm Width); Category: Drivers, Receivers, Transceivers; Component Kind: Standard; Data Rate: 600Mbps; Datasheet URL: https://www.digikey.com/en/products/detail/texas-instruments/DS90LV027AQMA-NOPB/1888061; Max Operating Temperature: 85°C; Min Operating Temperature: -40°C; Mounting Type: Surface Mount; Protocol: LVDS; RoHS: Yes; Series: Automotive, AEC-Q100; Supplier 1: Digikey; Supplier Part Number 1: DS90LV027AQMA/NOPB-ND; Type: Driver; Library Name: FRL Altium Server
U4 = INT-CMP-00017-4: IC, RVCR, DS90LV028AQ-Q1, DUAL, DIFF, LVDS, 400MBPS, SOIC-8; Manufacturer: Texas Instruments; Number of Drivers/Receivers: 0/2; Voltage - Supply: 3V ~ 3.6V; Case/Package: 8-SOIC (0.154", 3.90mm Width); Category: Drivers, Receivers, Transceivers; Component Kind: Standard; Data Rate: 400Mbps; Datasheet URL: https://www.digikey.com/en/products/detail/texas-instruments/DS90LV028AQMA-NOPB/13431640?s=N4IgTCBcDaICIGUCcAGAMgNRWAHAQQEUBZPAegDkB5ABQCEQBdAXyA; Max Operating Temperature: 125°C; Min Operating Temperature: -40°C; Mounting Type: Surface Mount; Protocol: LVDS; RoHS: Yes; Series: Automotive, AEC-Q100; Supplier 1: Digikey; Supplier Part Number 1: DS90LV028AQMA/NOPB-ND; Type: Receiver; Library Name: FRL Altium Server
U5 = SNS-CMP-00004-1: SENSOR DIGITAL -55C-125C 8HWSON; Manufacturer: NXP USA Inc.; Output Type: I²C/SMBus; Resolution (Bits): 11 b; Sensing Temperature - Local: -55°C ~ 125°C; Sensor Type: Digital, Local; Voltage - Supply: 2.7V ~ 5.5V; Case/Package: 8-WFDFN Exposed Pad; Category: Temperature Sensors - Analog and Digital Output; Component Kind: Standard; Datasheet URL: https://www.digikey.com/en/products/detail/nxp-usa-inc/PCT2075TP-147/3991863?s=N4IgTCBcDaIAoGEAqYAMB2ArEuAaAjACzogC6AvkA; Features: Output Switch, Programmable Limit; Max Operating Temperature: 125°C; Min Operating Temperature: -55°C; Mounting Type: Surface Mount; RoHS: Yes; Series: PCT2075; Supplier 1: Digikey; Supplier Part Number 1: 568-10220-1-ND; Library Name: FRL Altium Server
Y1 = COR-CMP-00010-2: OSC, OCXO, 10MHZ - 20MHZ, 0.5PPB STAB, 3.3V, 22.0X25.4X12.3MM, SMT; Manufacturer: Rakon; Frequency Stability: ±0.5ppm; Case/Package: 7-SMD, No Lead; Category: Oscillators; Frequency: 10 MHz; Height: 12.3mm; Max Operating Temperature: 85°C; Min Operating Temperature: -40°C; Mounting Type: Surface Mount; RoHS: Yes; Size / Dimension: 22.00mm x 25.00mm; Type: OCXO; Voltage - Supply: 3.3V; Library Name: FRL Altium Server
Y2 = COR-CMP-00012-1: OSC, OCXO, 10.000MHZ, 5PPM STAB, LVCMOS/CLIPPED SINE, 3.3V, DFN-10; Manufacturer: SiTime; Frequency: 10 MHz; Frequency Stability: +5ppm; Output: LVCMOS; Case/Package: 10-DFN; Category: Oscillators; Height: 6.19mm; Max Operating Temperature: 70°C; Min Operating Temperature: -20°C; Mounting Type: Surface Mount; RoHS: Yes; Size / Dimension: 7.0mm x 9.0mm; Voltage - Supply: 3.3V; Library Name: FRL Altium Server
Y3 = COR-CMP-00013-2: OSC, OCXO, 10.000MHZ, 25PPB STAB, LVCMOS, 3.3V, 9.7X7.5X4.3MM, SMT; Manufacturer: Abracon LLC; Frequency: 10 MHz; Load Capacitance: 15pF; Case/Package: 4-SMD, No Lead; Category: Crystals; Datasheet URL: https://www.digikey.com/en/products/detail/abracon-llc/AOCJYR-10-000MHZ-M5625LF/4989029; Frequency Stability: ±25ppb; Height: 0.169" (4.30mm); Max Operating Temperature: 85°C; Min Operating Temperature: -40°C; Mounting Type: Surface Mount; Output: LVCMOS; RoHS: Yes; Size / Dimension: 0.382" L x 0.295" W (9.70mm x 7.50mm); Supplier 1: Digikey; Supplier Part Number 1: 535-12623-ND; Type: OCXO; Voltage - Supply: 3.3V; Library Name: FRL Altium Server
Y4 = COR-CMP-00014-2: OSC, OCXO, RFPO45, 10 - 26MHZ, 10PPB STAB, HCMOS, 3.3V, 9.7X7.5X4.3MM, SMT; Manufacturer: Rakon; Frequency: 10 MHz; Frequency Stability: ±10ppm; Case/Package: 4-SMD; Category: Oscillators; Height: 4.12mm; Max Operating Temperature: 70°C; Min Operating Temperature: -20°C; Mounting Type: Surface Mount; RoHS: Yes; Size / Dimension: 9x7x4mm; Type: OCXO; Voltage - Supply: 3.3V; Library Name: FRL Altium Server
Y5 = CMP-OSC-00029-2: OSC, MEMS, STRATUM 3E, 10.000MHZ, 10PPB STAB, LVCMOS, 3.3V, O/P EN, 7.0X5.0X2.2MM, CQFN-10; Manufacturer: SiTime; Frequency: 10 MHz; Case/Package: 10 Lead QFN; Category: Oscillators; Frequency Stability: ±10 ppb; Height: 2.20mm (max); Max Operating Temperature: 70°C; Min Operating Temperature: -20°C; Mounting Type: Surface Mount; Output: LVCMOS; RoHS: Yes; Type: OCXO; Voltage - Supply: 3.3 V; Library Name: Meta RLR Altium Enterprise Server
